# Altium schematic sheet 1-Top.SchDoc(1-Top)
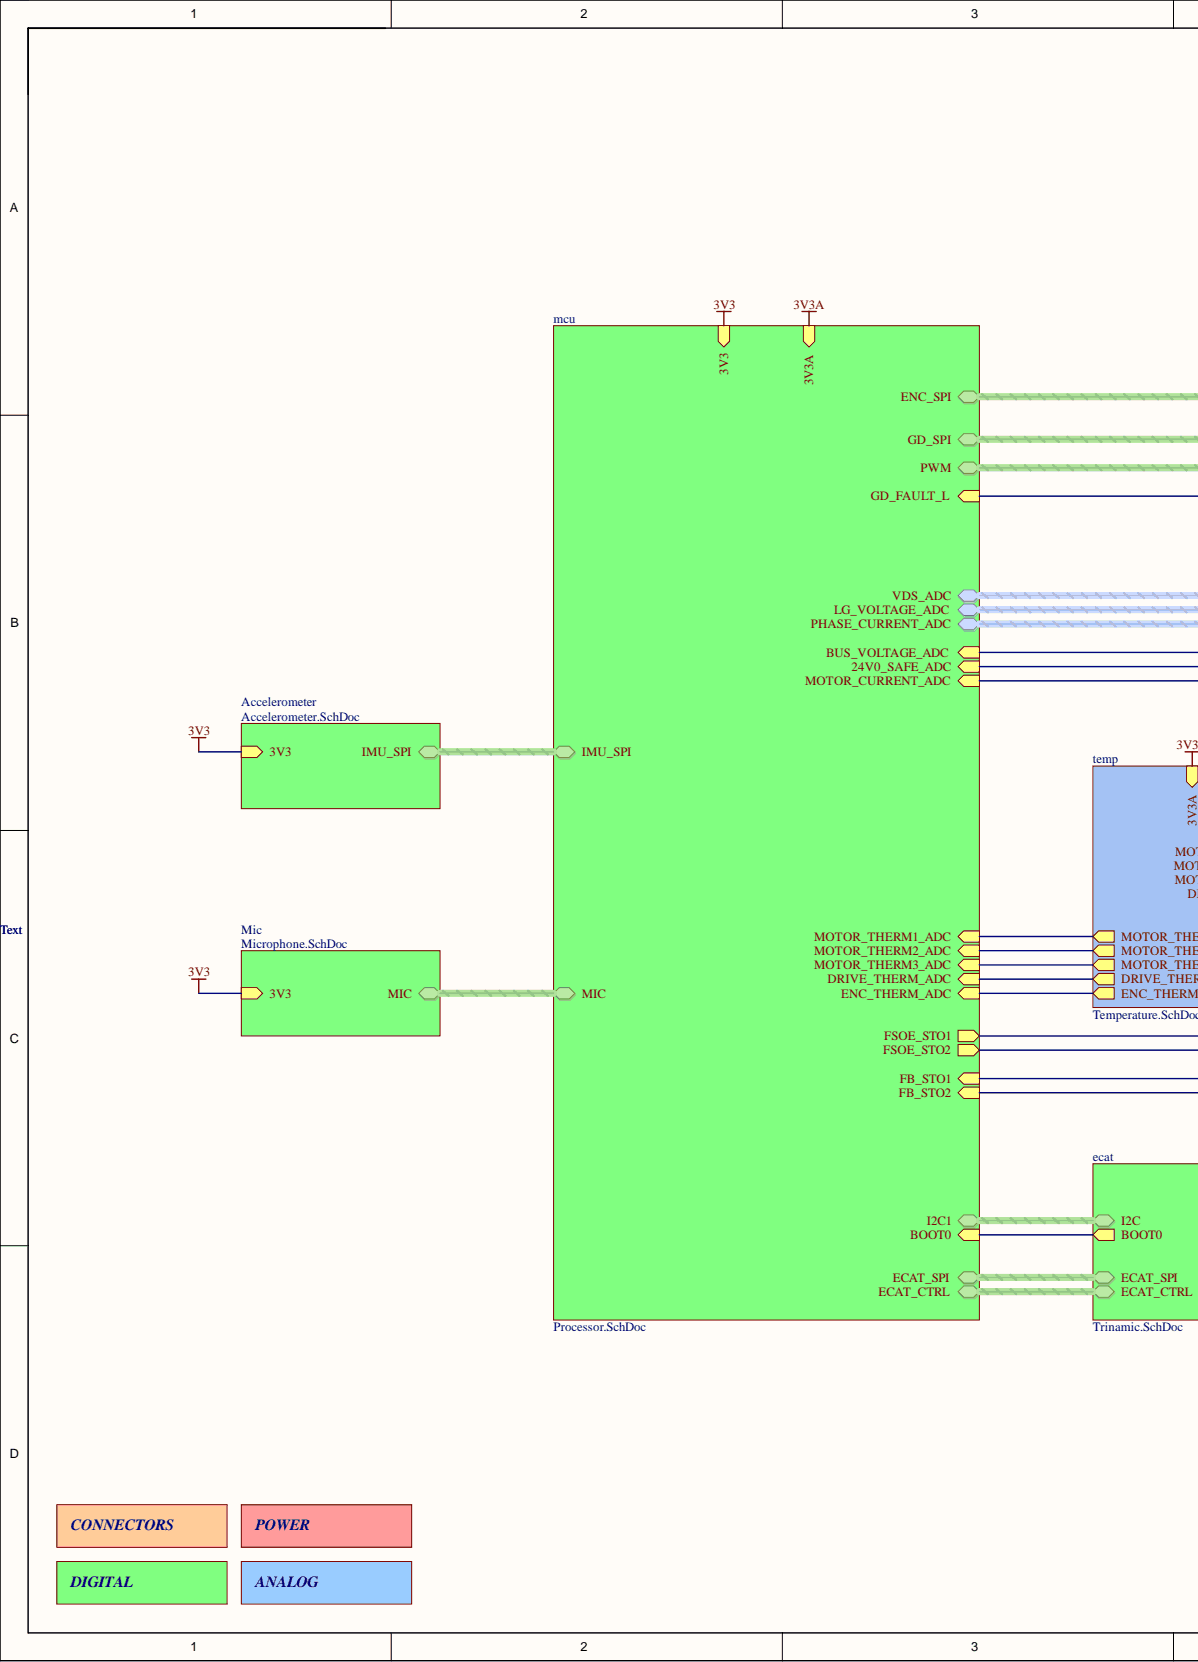
[diagram p0: 1-Top.SchDoc, left]
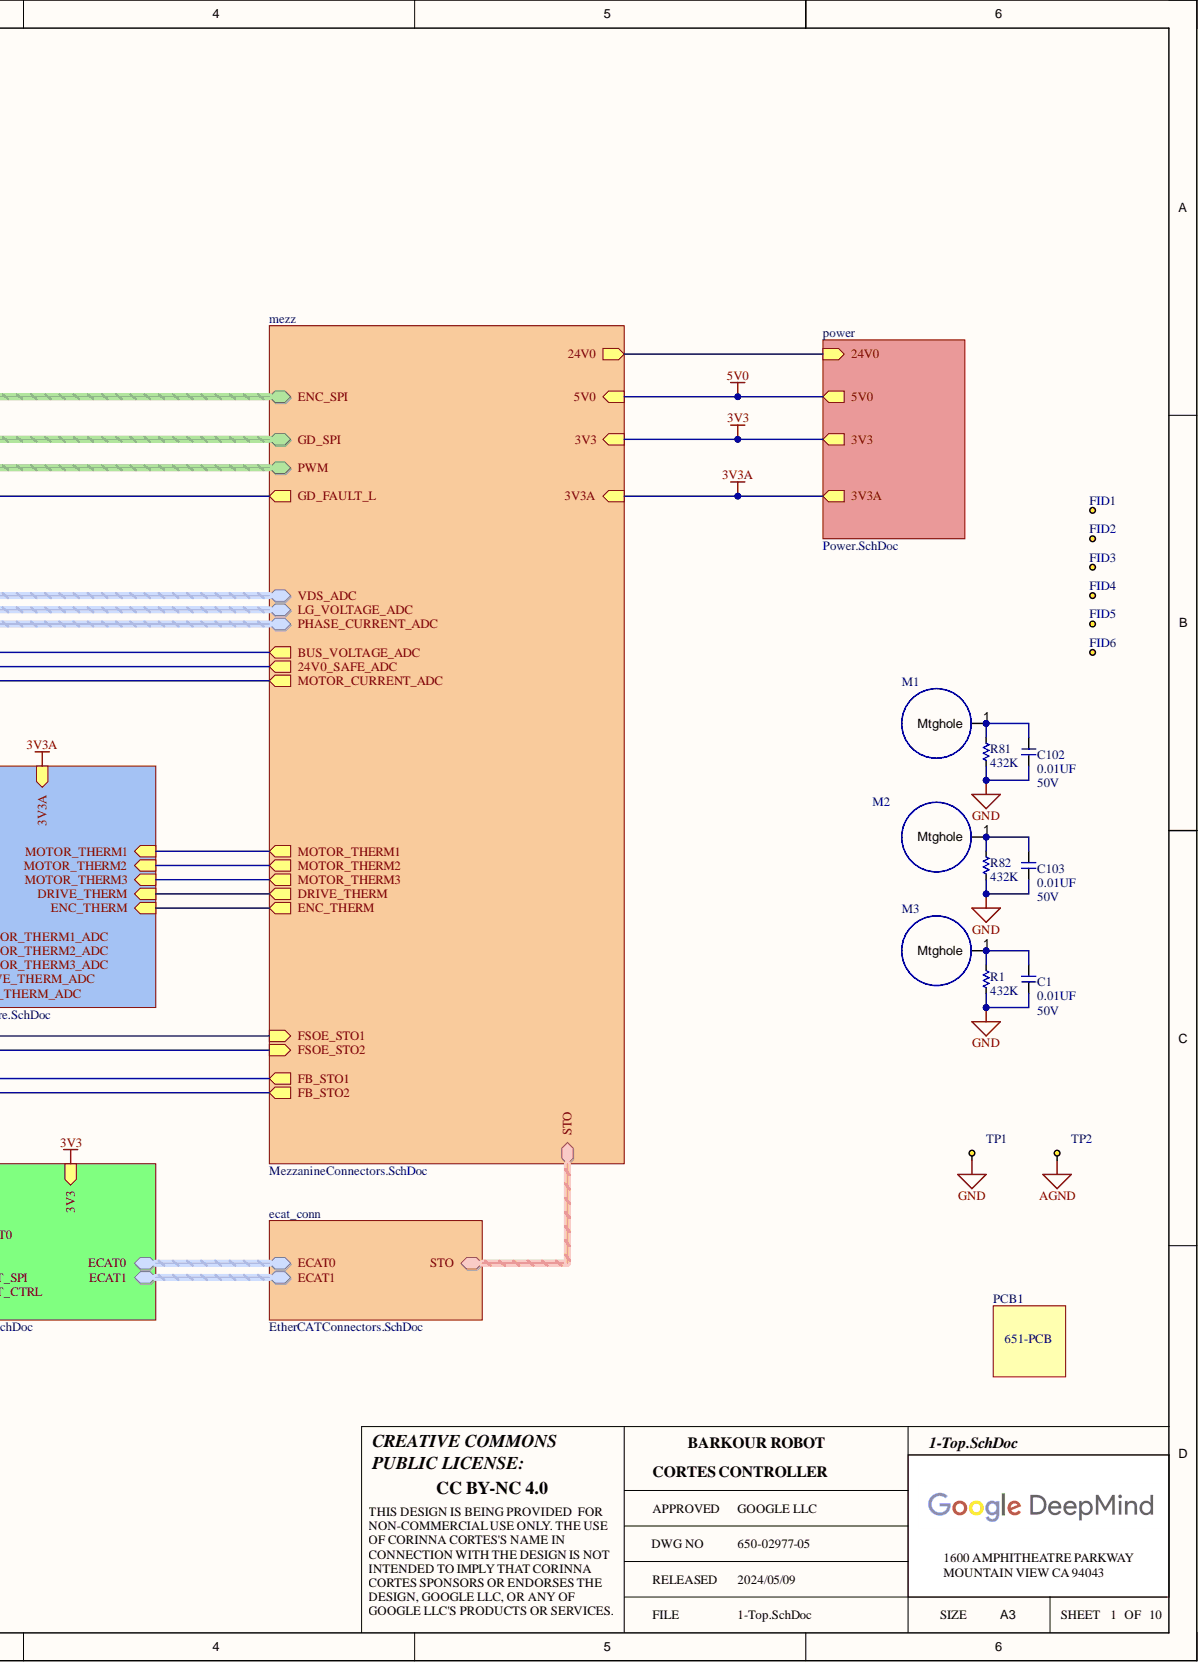
[diagram p1: 1-Top.SchDoc, right]

## Parts
C1  value 0.01UF  Voltage: 50V  [C0402]  (hidden comment: __Template_Cap)
C102  value 0.01UF  Voltage: 50V  [C0402]  (hidden comment: __Template_Cap)
C103  value 0.01UF  Voltage: 50V  [C0402]  (hidden comment: __Template_Cap)
FID1  FIDUCIAL  [FIDUCIAL_1MM]  (value not drawn)  (no BOM)
FID2  FIDUCIAL  [FIDUCIAL_1MM]  (value not drawn)  (no BOM)
FID3  FIDUCIAL  [FIDUCIAL_1MM]  (value not drawn)  (no BOM)
FID4  FIDUCIAL  [FIDUCIAL_1MM]  (value not drawn)  (no BOM)
FID5  FIDUCIAL  [FIDUCIAL_1MM]  (value not drawn)  (no BOM)
FID6  FIDUCIAL  [FIDUCIAL_1MM]  (value not drawn)  (no BOM)
M1  3.7mm hole 5mm pad  [3.7hole5pad]  (value not drawn)
M2  3.7mm hole 5mm pad  [3.7hole5pad]  (value not drawn)
M3  3.7mm hole 5mm pad  [3.7hole5pad]  (value not drawn)
PCB1  PCB  (mechanical)
R1  value 432K  [R0805]
R81  value 432K  [R0805]
R82  value 432K  [R0805]
TP1  TEST_KEYSTONE5001  [TP_KEYSTONE_5001]  (value not drawn)
TP2  TEST_KEYSTONE5001  [TP_KEYSTONE_5001]  (value not drawn)

## Nets
AGND: TP2.1
GND: C1.2 C102.2 C103.2 R1.1 R81.1 R82.1 TP1.1
NetC1_1: C1.1 M3.1 R1.2
NetC102_1: C102.1 M1.1 R81.2
NetC103_1: C103.1 M2.1 R82.2
3V3:
3V3A:
5V0:
N00001:
N00002:
N00003:
N00004:
N00005:
N00006:
N00007:
N00008:
N00009:
N00010:
N00011:
N00012:
N00013:
N00014:
N00016:
N00017:
N00018:
N00019:
N00020:
N00021:
N00022:
N00023:
N00024:
N00025:
N00026:
N00027:
N00028:
N00029:
N00030:
N00031:
N00032:
N00033:
N00034:
N00035:
N00036:
N00037:
N00038:
N00039:
N00040:
N00041:
N00042:
N00043:
N00044:
N00045:
N00046:
N00047:
N00048:
N00049:
N00050:
N00051:
N00052:
N00053:
N00054:
N00055:
N00056:
N00057:
N00058:
N00059:
N00060:
N00061:
N00062:
N00063:
N00064:
N00065:
N00066:
N00067:
N00068:
N00069:
N00070:
N00071:
N00072:
N00073:
N00074:
N00075:
N00076:
N00077:
N00078:
N00079:
N00080:
N00081:
N00082:
N00083:
N00084:
N00085:
N00086:
N00087:
N00088:
N00089:
N00090:

## Library data of the parts
C1 C102 C103 = 625: CAP, CER, X7R, 0.01uF, 50V, 20%, 0402, 0.56mm T; COMMON: 150-00227-00; Database Table Name: Capacitors; EES: 150-04592-00; MFG: KEMET; MFG P/N: C0402C103M5RACTU; Component Kind: Standard; ComponentLink1Description: Datasheet; Dielectric: X7R; Gecko: 150-00227-00; Height: 0.56mm T; ID: 625; Library Name: AltiumReleaseLibDB.DBLib; LOON: 150-00227-00; Package: 0402; Status: Released; Tolerance: 20%; XPN: 150-00227-00
FID1-FID6 = 93: FIDUCIAL 1MM COPPER CIRCLE 3MM MASK PULLBACK; Database Table Name: Miscellaneous; MFG: _PCB Feature; ID: 93; Library Name: AltiumReleaseLibDB.DBLib; Status: In Development
M1-M3 = MtgHole: Component Kind: Standard; Description: 3.7mm hole 5mm pad; Library Name: MiscSch1.SchLib
PCB1 = 110: Database Table Name: Miscellaneous; FindNumber: 010; Level: 1; Org: ORG - REP; Description: PCB; ID: 110; Library Name: AltiumReleaseLibDB.DBLib; ProductLine: Proxy; Status: In Development; Type: PCB
R1 R81 R82 = 5492: Resistor, 432K Ohms, 0805, 0.125W, ±1%; COMMON: 470-08723-00; Database Table Name: Resistors; EES: 470-17987-00; MFG: YAGEO; MFG P/N: RC0805FR-07432KL; Power: 1/8W; XPN: XS-10019230; Component Kind: Standard; Gecko: 470-08723-00; Height: 0.60mm; ID: 5492; Library Name: AltiumReleaseLibDB.DBLib; LOON: 470-08723-00; Package: 0805; Status: Released; Supplier 1: Digi-Key; Supplier Part Number 1: 311-432KCRCT-ND; Tolerance: 1%
TP1 TP2 = TEST_KEYSTONE5001: PC TEST POINT TH RING; Manufacturer: Keystone Electronics; Manufacturer Part Number: 5001; Part Number: 250-00184-00; Category: Test and Measurement; Color: Black; Component Kind: Standard; ComponentLink1Description: http://www.keyelco.com/product-pdf.cfm?p=1310; ComponentLink1URL: http://www.keyelco.com/product-pdf.cfm?p=1310; Library Name: miscellaneous.SchLib; Material: Base, Nylon 46, UL 94V-0; Mounting Type: 0.040" (1.02mm) Hole Diameter; Packaging: Bulk; Pricing 1: 1=0.33, 10=0.309, 50=0.2252, 100=0.2164, 250=0.19432, 500=0.18548, 1000=0.15456, 2500=0.14131, 5000=0.13248 (USD); RoHS: RoHS Compliant; Stock 1: 341830; Supplier 1: Digi-Key; Supplier Part Number 1: 5001K-ND; Terminal: 0.010" x 0.020" (0.25mm x 0.51mm) Phosphor Bronze Wire, Silver Plate; Type: PC Test Point, Miniature
